# Altium schematic sheet 05 SERVO_FAN.SchDoc
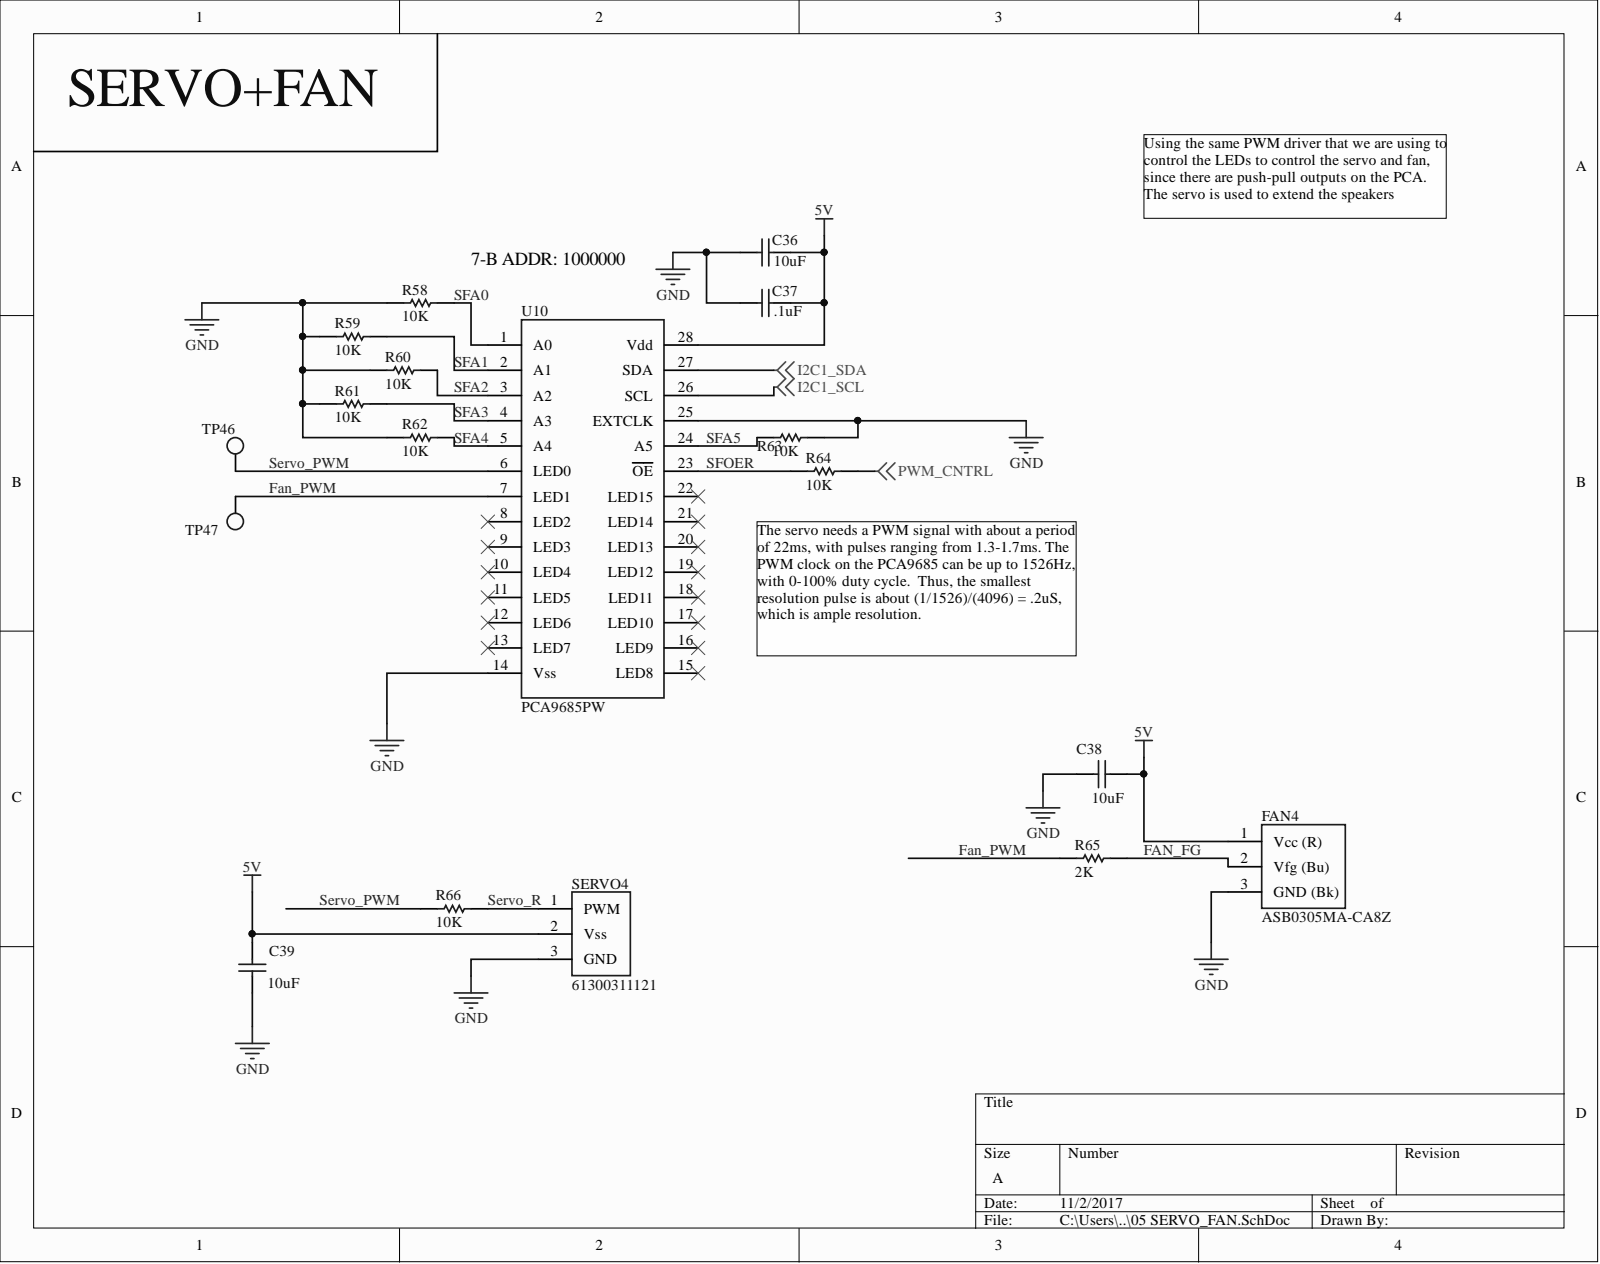
[diagram p0: 05 SERVO_FAN.SchDoc]

## Parts
C36  value 10uF  Capacitance: 10uF  [CAPC2012X135N]  (hidden comment: CAP_0805_10u_16V)
C37  value .1uF  [CAPC2012X135N]  (hidden comment: CAP_0805_.1u_250V)
C38  value 10uF  Capacitance: 10uF  [CAPC2012X135N]  (hidden comment: CAP_0805_10u_16V)
C39  value 10uF  Capacitance: 10uF  [CAPC2012X135N]  (hidden comment: CAP_0805_10u_16V)
FAN4  ASB0305MA-CA8Z  [FAN_HEADER]
R58  Resistance: 10K  [RES0805]  (hidden comment: RES_0805_10K)
R59  Resistance: 10K  [RES0805]  (hidden comment: RES_0805_10K)
R60  Resistance: 10K  [RES0805]  (hidden comment: RES_0805_10K)
R61  Resistance: 10K  [RES0805]  (hidden comment: RES_0805_10K)
R62  Resistance: 10K  [RES0805]  (hidden comment: RES_0805_10K)
R63  Resistance: 10K  [RES0805]  (hidden comment: RES_0805_10K)
R64  Resistance: 10K  [RES0805]  (hidden comment: RES_0805_10K)
R65  RES_0805_2K  [RES0805]  (value not drawn)
R66  Resistance: 10K  [RES0805]  (hidden comment: RES_0805_10K)
SERVO4  61300311121  [SERVO_HEADER]
TP46  TP  [TestPoint_0805]
TP47  TP  [TestPoint_0805]
U10  PCA9685PW  [TSSOP28]

## Nets
5V: C36.2 C37.2 C38.1 C39.2 FAN4.1 SERVO4.2 U10.28(Vdd)
GND: C36.1 C37.1 C38.2 C39.1 FAN4.3 R58.1 R59.1 R60.1 R61.1 R62.1 R63.2 SERVO4.3 U10.14(Vss) U10.25(EXTCLK)
I2C1_SCL: U10.26(SCL)
I2C1_SDA: U10.27(SDA)
PWM_CNTRL: R64.2
FAN_FG: FAN4.2 R65.2
Fan_PWM: R65.1 TP47.1 U10.7(LED1)
Servo_PWM: R66.1 TP46.1 U10.6(LED0)
Servo_R: R66.2 SERVO4.1
SFA0: R58.2 U10.1(A0)
SFA1: R59.2 U10.2(A1)
SFA2: R60.2 U10.3(A2)
SFA3: R61.2 U10.4(A3)
SFA4: R62.2 U10.5(A4)
SFA5: R63.1 U10.24(A5)
SFOER: R64.1 U10.23(OE)

Not connected: U10 8(LED2) 9(LED3) 10(LED4) 11(LED5) 12(LED6) 13(LED7) 15(LED8) 16(LED9) 17(LED10) 18(LED11) 19(LED12) 20(LED13) 21(LED14) 22(LED15)

## Library data of the parts
C36 C38 C39 = CAP_0805_10u_16V: Cap Ceramic 10uF 16V X5R 10% SMD 0805 85C T/R; Manufacturer: Johanson Dielectrics; Manufacturer Part Number: 160R15X106KV4E; Case/Package: 0805; Case Code (Imperial): 0805; Case Code (Metric): 2012; Component Kind: Standard; ComponentLink1Description: https://datasheet.ciiva.com/26948/getdatasheetpartid-132904-26948555.pdf; ComponentLink1URL: https://datasheet.ciiva.com/26948/getdatasheetpartid-132904-26948555.pdf; Depth: 1.27mm; Dielectric: X5R; Height: 1.27mm; Length: 2.03mm; Max Operating Temperature: 85°C; Min Operating Temperature: -55°C; Mount: Surface Mount; Number of Pins: 2; Package Quantity: 2000; Packaging: Tape and Reel; Pricing 1: 1=0.55, 10=0.387, 100=0.2552, 500=0.189, 1000=0.1607 (USD); Radiation Hardening: No; REACH SVHC: No SVHC; Stock 1: 36636; Supplier 1: Digi-Key; Supplier Part Number 1: 709-1229-1-ND; Termination: SMD/SMT; Thickness: 0.06inch; Tolerance: 10%; Voltage Rating: 16V; Voltage Rating (DC): 16V; Weight: 0.000194oz; Width: 1.27mm; Library Name: schlib_truman.SchLib
C37 = CAP_0805_.1u_250V: Cap Ceramic 0.1uF 250V X7T 10% SMD 0805 125C Plastic T/R; Manufacturer: TDK; Manufacturer Part Number: C2012X7T2E104K125AE; Capacitance: 100nF; Case/Package: 0805; Case Code (Imperial): 0805; Case Code (Metric): 2012; Component Kind: Standard; ComponentLink1Description: https://datasheet.ciiva.com/24219/c2012x7t2e104k125ae-265154-24219519.pdf; ComponentLink1URL: https://datasheet.ciiva.com/24219/c2012x7t2e104k125ae-265154-24219519.pdf; Composition: Ceramic; Depth: 1.25mm; Dielectric: X7T; Height: 1.25mm; Length: 2mm; Max Operating Temperature: 125°C; Min Operating Temperature: -55°C; Package Quantity: 1; Packaging: Tape and Reel; Pricing 1: 1=0.37, 10=0.25, 100=0.1419, 500=0.1002, 1000=0.0877 (USD); REACH SVHC: No SVHC; Stock 1: 30376; Supplier 1: Digi-Key; Supplier Part Number 1: 445-8883-1-ND; Termination: SMD/SMT; Thickness: 0.049inch; Tolerance: 10%; Voltage Rating: 250V; Voltage Rating (DC): 250V; Weight: 0.000194oz; Width: 0.05inch; Library Name: schlib_truman.SchLib
FAN4 = ASB0305MA-CA8Z: FAN AXIAL 30X10MM 5VDC WIRE; Manufacturer: Delta Design; Airflow: 3.4CFM; Bearing Type: Sleeve; Current Rating: 110mA; Max Power Dissipation: 550mW; Noise: 23dBA; RPM: 9000RPM; Component Kind: Standard; ComponentLink1Description: //media.digikey.com/pdf/Data%20Sheets/Delta%20PDFs/ASB0305MA-CA8Z_Spec.pdf; ComponentLink1URL: //media.digikey.com/pdf/Data%20Sheets/Delta%20PDFs/ASB0305MA-CA8Z_Spec.pdf; Manufacturer Part Number: ASB0305MA-CA8Z; Max Operating Temperature: 158°C; Min Operating Temperature: 14°C; Package Quantity: 720; Pricing 1: 1=6.96, 10=6.38, 50=5.365 (USD); Stock 1: 98; Supplier 1: Digi-Key; Supplier Part Number 1: 603-1847-ND; Termination: 3 Wire Leads; Weight: 0.015lb; Width: 10mm; Library Name: schlib_truman.SchLib
R58-R64 R66 = RES_0805_10K: RES, THICK FILM, 10K, 5%, 0.125W, 0805; Manufacturer: Yageo; Manufacturer Part Number: RC0805JR-0710KL; Power Rating: 125mW; Temperature Coefficient: 100ppm/°C; Voltage Rating: 150V; Case/Package: 0805; Case Code (Imperial): 0805; Case Code (Metric): 2012; Component Kind: Standard; ComponentLink1Description: https://datasheet.ciiva.com/7983/444164-7983765.pdf; ComponentLink1URL: https://datasheet.ciiva.com/7983/444164-7983765.pdf; ComponentLink2Description: https://datasheet.ciiva.com/7941/444164-7941870.pdf; ComponentLink2URL: https://datasheet.ciiva.com/7941/444164-7941870.pdf; ComponentLink3Description: https://datasheet.ciiva.com/7941/444164-7941871.pdf; ComponentLink3URL: https://datasheet.ciiva.com/7941/444164-7941871.pdf; Composition: Thick Film; Contact Plating: Tin,Matte; Depth: 1.25mm; Height: 0.5mm; Lead Free: Lead Free; Length: 2mm; Max Operating Temperature: 155°C; Military Standard: Not; Min Operating Temperature: -55°C; Packaging: Tape and Reel; Pricing 1: 1=0.1, 10=0.019, 100=0.0076, 1000=0.0034, 2500=0.003 (USD); Radiation Hardening: No; REACH SVHC: Unknown; Stock 1: 1285290; Supplier 1: Digi-Key; Supplier Part Number 1: 311-10KARCT-ND; Termination: SMD/SMT; Tolerance: 5%; Width: 1.25mm; Library Name: schlib_truman.SchLib
R65 = RES_0805_2K: RES SMD 2K OHM 5% 1/8W 0805; Manufacturer: Yageo; Manufacturer Part Number: RC0805JR-072KL; Max Power Dissipation: 125mW; Resistance: 2KR; Temperature Coefficient: 100ppm/°C; Voltage Rating: 150V; : 2K; Case/Package: 0805; Case Code (Imperial): 0805; Case Code (Metric): 2012; Component Kind: Standard; ComponentLink1Description: https://datasheet.ciiva.com/46395/444164-46395141.pdf; ComponentLink1URL: https://datasheet.ciiva.com/46395/444164-46395141.pdf; ComponentLink2Description: https://datasheet.ciiva.com/2456/pyu-r-int-thick-7-2456104.pdf; ComponentLink2URL: https://datasheet.ciiva.com/2456/pyu-r-int-thick-7-2456104.pdf; ComponentLink3Description: https://datasheet.ciiva.com/2456/pyu-r-int-thick-7-2456105.pdf; ComponentLink3URL: https://datasheet.ciiva.com/2456/pyu-r-int-thick-7-2456105.pdf; ComponentLink4Description: https://datasheet.ciiva.com/2456/pyu-r-int-thick-7-2456106.pdf; ComponentLink4URL: https://datasheet.ciiva.com/2456/pyu-r-int-thick-7-2456106.pdf; ComponentLink5Description: https://datasheet.ciiva.com/12666/rc0805-12666941.pdf; ComponentLink5URL: https://datasheet.ciiva.com/12666/rc0805-12666941.pdf; Composition: Thick Film; Contact Plating: Tin,Lead,Matte; Depth: 1.25mm; Height: 0.024inch; Lead Free: Lead Free; Length: 2mm; Max Operating Temperature: 155°C; Military Standard: Not; Min Operating Temperature: -55°C; Number of Pins: 2; Number of Terminations: 2; Package Quantity: 1; Packaging: Tape and Reel; Power Rating: 125mW; Pricing 1: 1=0.1, 10=0.016, 25=0.0116, 50=0.009, 100=0.0066, 250=0.005, 500=0.004, 1000=0.003 (USD); Radiation Hardening: No; REACH SVHC: No SVHC; Stock 1: 297667; Supplier 1: Digi-Key; Supplier Part Number 1: 311-2.0KARCT-ND; Termination: SMD/SMT; Tolerance: 5%; Voltage Rating (DC): 150V; Width: 0.049inch; Library Name: schlib_truman.SchLib
SERVO4 = Servo_Header: Board-To-Board Connector, Vertical, WR-PHD Series, Through Hole, Header, 3 Contacts, 2.54 mm; Manufacturer: Wurth Electronics; Connector Type: Male Pin; Gender: Male; Number of Contacts: 3; Pitch: 0.1inch; Case/Package: 100; Colour: Black; Component Kind: Standard; ComponentLink1Description: http://www.farnell.com/cad/1737268.pdf; ComponentLink1URL: http://www.farnell.com/cad/1737268.pdf; Contact Material: Copper; Contact Mating Length: 0.236inch; Contact Plating: Gold; Lead Free: Lead Free; Manufacturer Part Number: 61300311121; Mount: Through Hole; Number of Positions: 3; Number of Rows: 1; Packaging: Bulk; Pricing 2: 1=0.13, 10=0.106, 25=0.088, 50=0.074, 100=0.067, 1000=0.061 (USD); REACH SVHC: Unknown; Stock 2: 24543; Supplier 1: Digi-Key; Supplier 2: Digi-Key; Supplier Part Number 1: 900-00008-ND; Supplier Part Number 2: 732-5316-ND; Termination: Solder; Library Name: schlib_truman.SchLib
TP46 TP47 = TP: Testpoint_0805; Manufacturer: TE Connectivity; Manufacturer Part Number: 1625854-2; Resistance: 0mR; Case/Package: 0805; Colour: Natural; Component Kind: Standard; ComponentLink1Description: https://datasheet.ciiva.com/6439/eng-ds-1773266-a-6439169.pdf; ComponentLink1URL: https://datasheet.ciiva.com/6439/eng-ds-1773266-a-6439169.pdf; ComponentLink2Description: https://datasheet.ciiva.com/30238/1773266-a-30238966.pdf; ComponentLink2URL: https://datasheet.ciiva.com/30238/1773266-a-30238966.pdf; ComponentLink3Description: https://datasheet.ciiva.com/8914/eng-ds-1773266-a-8914649.pdf; ComponentLink3URL: https://datasheet.ciiva.com/8914/eng-ds-1773266-a-8914649.pdf; Depth: 1.2mm; Lead Free: Lead Free; Length: 2mm; Max Operating Temperature: 125°C; Military Standard: Not; Min Operating Temperature: -55°C; Mount: Surface Mount; Package Quantity: 2000; Packaging: Tape and Reel; Pricing 1: 1=0.21, 10=0.2, 25=0.1708, 50=0.1496, 100=0.1389, 250=0.1229, 500=0.1175, 1000=0.0962 (USD); Radiation Hardening: No; Stock 1: 27299; Supplier 1: Digi-Key; Supplier Part Number 1: A106144CT-ND; Library Name: schlib_truman.SchLib
U10 = PCA9685PW: IC LED DRIVER RGBA 28-TSSOP; Manufacturer: NXP Semiconductors; Manufacturer Part Number: PCA9685PW,118; Frequency: 1MHz; High Level Output Current: 10mA; Low Level Output Current: 400A; Max Supply Voltage: 5.5V; Min Supply Voltage: 2.3V; Nominal Supply Current: 10A; Number of Outputs: 16; Output Current per Channel: 25mA; Power Dissipation: 400W; Case/Package: TSSOP; Component Kind: Standard; ComponentLink1Description: https://datasheet.ciiva.com/1947/pca9685-1947062.pdf; ComponentLink1URL: https://datasheet.ciiva.com/1947/pca9685-1947062.pdf; ComponentLink2Description: https://datasheet.ciiva.com/15134/getdatasheetpartid-103066-15134201.pdf; ComponentLink2URL: https://datasheet.ciiva.com/15134/getdatasheetpartid-103066-15134201.pdf; ComponentLink3Description: https://datasheet.ciiva.com/8125/pca9685-8125357.pdf; ComponentLink3URL: https://datasheet.ciiva.com/8125/pca9685-8125357.pdf; Lead Free: Lead Free; Max Operating Temperature: 85°C; Min Operating Temperature: -40°C; Mount: Surface Mount; Number of Pins: 28; Output Voltage: 5.5V; Package Quantity: 2500; Packaging: Tape and Reel; Pricing 1: 1=2.31, 10=2.074, 100=1.6674, 500=1.3699, 1000=1.1351 (USD); Radiation Hardening: No; Stock 1: 2599; Supplier 1: Digi-Key; Supplier Part Number 1: 568-11925-1-ND; Library Name: schlib_truman.SchLib
